# Altium schematic sheet Debug.SchDoc(Debug)
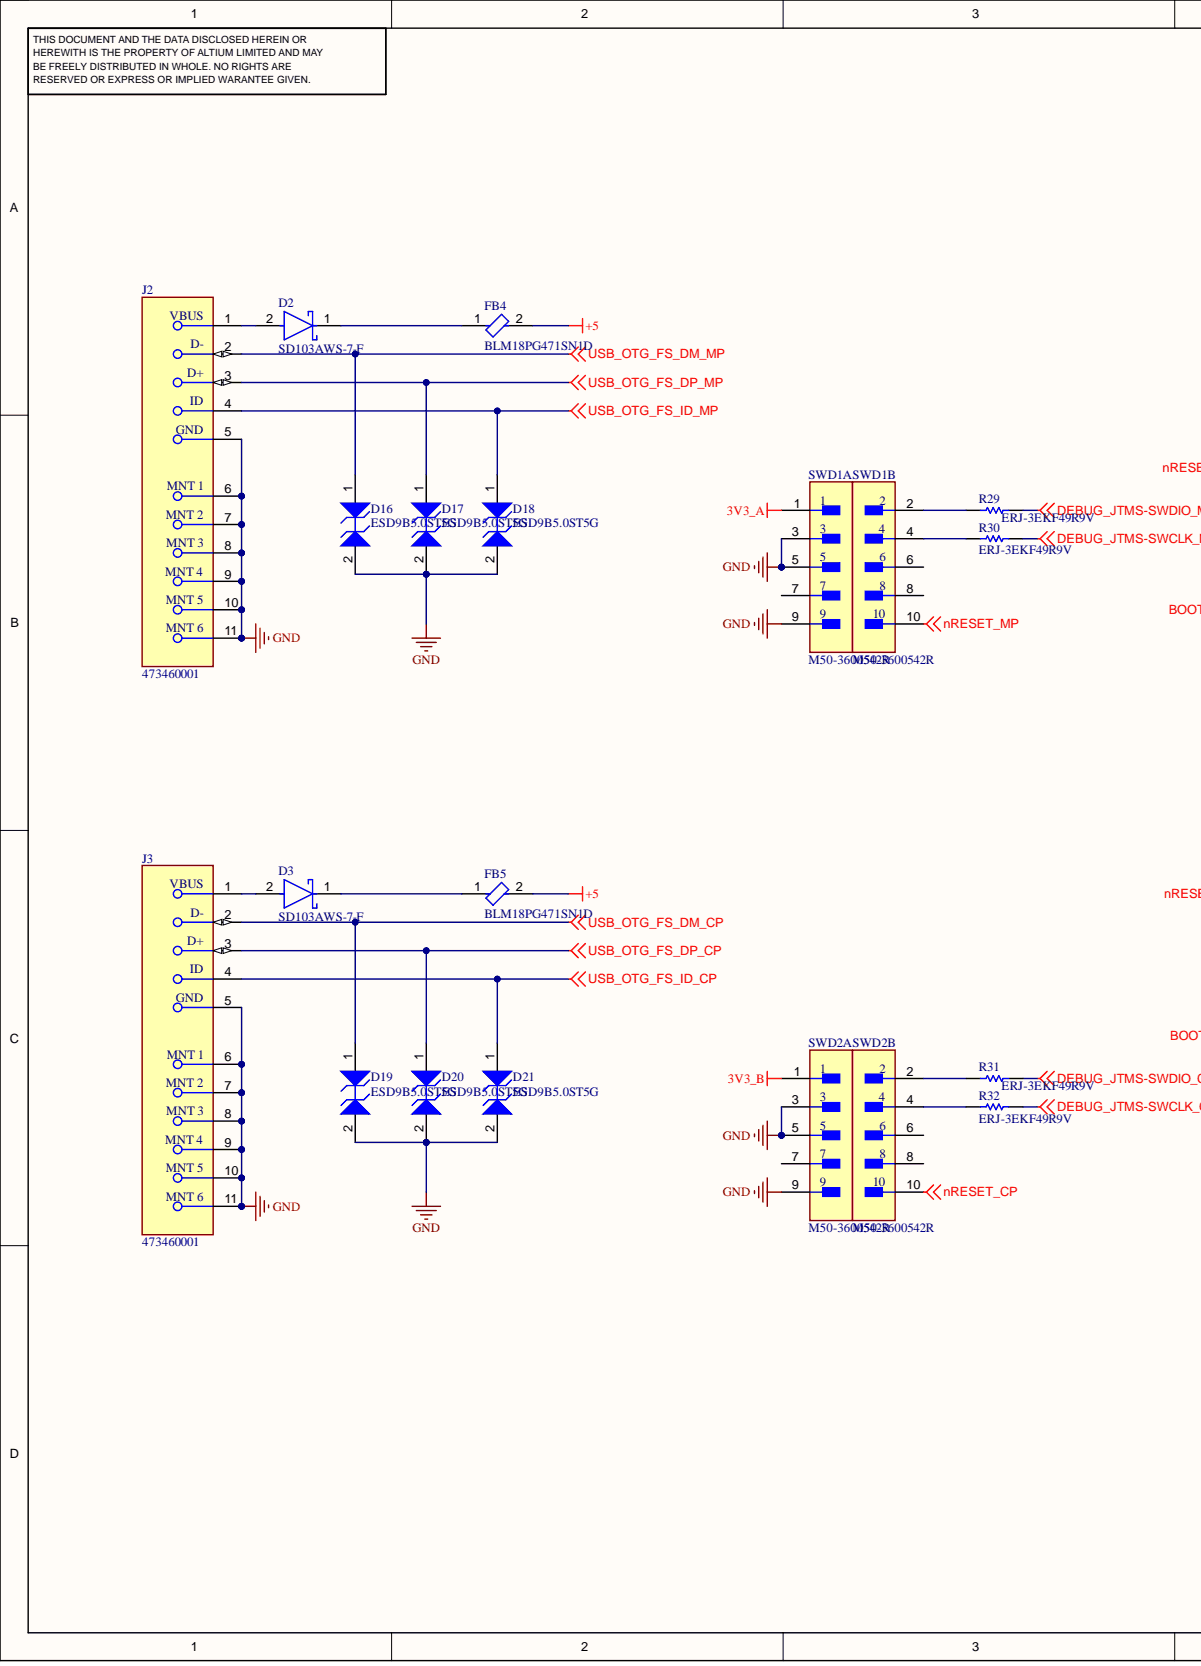
[diagram p0: Debug.SchDoc, left]
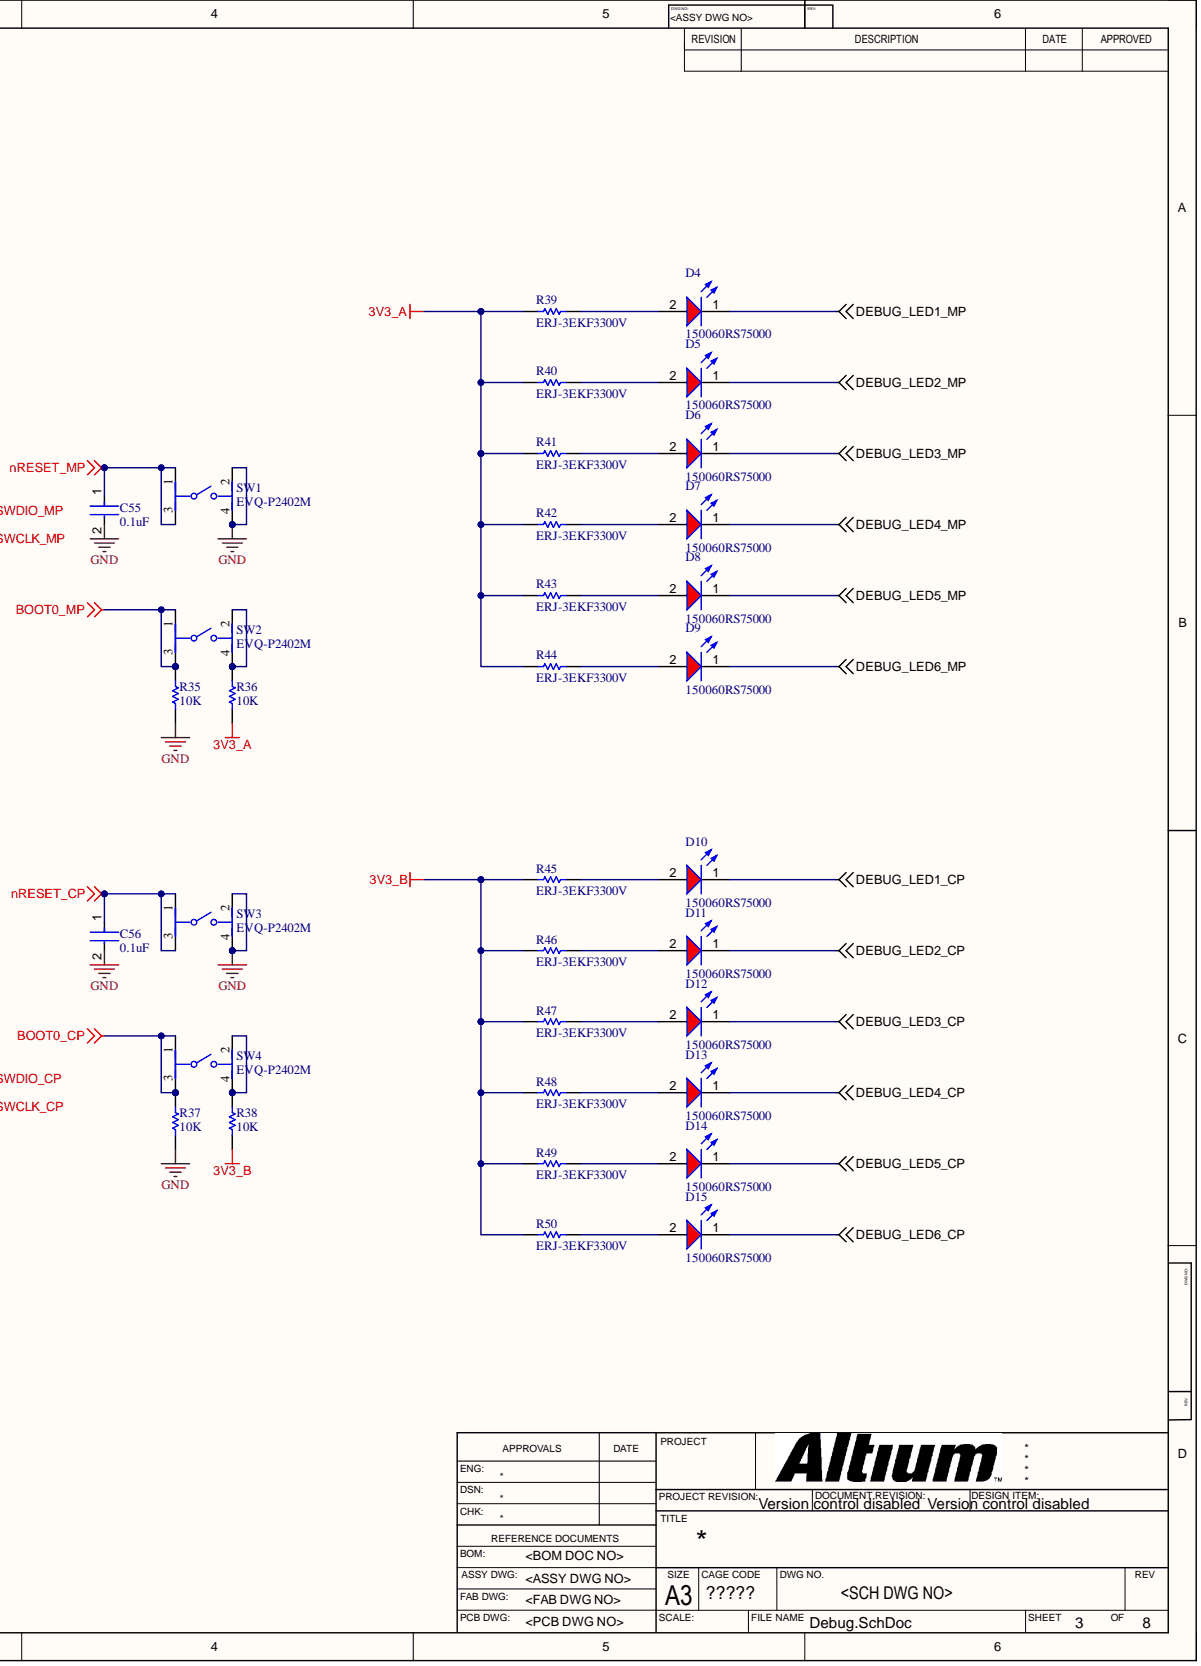
[diagram p1: Debug.SchDoc, right]

## Parts
C55  0.1uF
C56  0.1uF
D2  SD103AWS-7-F
D3  SD103AWS-7-F
D4  150060RS75000
D5  150060RS75000
D6  150060RS75000
D7  150060RS75000
D8  150060RS75000
D9  150060RS75000
D10  150060RS75000
D11  150060RS75000
D12  150060RS75000
D13  150060RS75000
D14  150060RS75000
D15  150060RS75000
D16  ESD9B5.0ST5G
D17  ESD9B5.0ST5G
D18  ESD9B5.0ST5G
D19  ESD9B5.0ST5G
D20  ESD9B5.0ST5G
D21  ESD9B5.0ST5G
FB4  BLM18PG471SN1D
FB5  BLM18PG471SN1D
J2  473460001
J3  473460001
R29  ERJ-3EKF49R9V
R30  ERJ-3EKF49R9V
R31  ERJ-3EKF49R9V
R32  ERJ-3EKF49R9V
R35  10K
R36  10K
R37  10K
R38  10K
R39  ERJ-3EKF3300V
R40  ERJ-3EKF3300V
R41  ERJ-3EKF3300V
R42  ERJ-3EKF3300V
R43  ERJ-3EKF3300V
R44  ERJ-3EKF3300V
R45  ERJ-3EKF3300V
R46  ERJ-3EKF3300V
R47  ERJ-3EKF3300V
R48  ERJ-3EKF3300V
R49  ERJ-3EKF3300V
R50  ERJ-3EKF3300V
SW1  EVQ-P2402M
SW2  EVQ-P2402M
SW3  EVQ-P2402M
SW4  EVQ-P2402M
SWD1  M50-3600542R  (parts A,B)
SWD2  M50-3600542R  (parts A,B)

## Nets
3V3_A: R36.1 R39.1 R40.1 R41.1 R42.1 R43.1 R44.1 SWD1.1
3V3_B: R38.1 R45.1 R46.1 R47.1 R48.1 R49.1 R50.1 SWD2.1
+5: FB4.2 FB5.2
BOOT0_CP: R37.2 SW4.1 SW4.3
BOOT0_MP: R35.2 SW2.1 SW2.3
DEBUG_JTMS-SWCLK_CP: R32.2
DEBUG_JTMS-SWCLK_MP: R30.2
DEBUG_JTMS-SWDIO_CP: R31.2
DEBUG_JTMS-SWDIO_MP: R29.2
DEBUG_LED1_CP: D10.1
DEBUG_LED1_MP: D4.1
DEBUG_LED2_CP: D11.1
DEBUG_LED2_MP: D5.1
DEBUG_LED3_CP: D12.1
DEBUG_LED3_MP: D6.1
DEBUG_LED4_CP: D13.1
DEBUG_LED4_MP: D7.1
DEBUG_LED5_CP: D14.1
DEBUG_LED5_MP: D8.1
DEBUG_LED6_CP: D15.1
DEBUG_LED6_MP: D9.1
GND: C55.2 C56.2 D16.2 D17.2 D18.2 D19.2 D20.2 D21.2 J2.5 J2.6 J2.7 J2.8 J2.9 J2.10 J2.11 J3.5 J3.6 J3.7 J3.8 J3.9 J3.10 J3.11 R35.1 R37.1 SW1.2 SW1.4 SW3.2 SW3.4 SWD1.3 SWD1.5 SWD1.9 SWD2.3 SWD2.5 SWD2.9
nRESET_CP: C56.1 SW3.1 SW3.3 SWD2.10
nRESET_MP: C55.1 SW1.1 SW1.3 SWD1.10
USB_OTG_FS_DM_CP: D19.1 J3.2
USB_OTG_FS_DM_MP: D16.1 J2.2
USB_OTG_FS_DP_CP: D20.1 J3.3
USB_OTG_FS_DP_MP: D17.1 J2.3
USB_OTG_FS_ID_CP: D21.1 J3.4
USB_OTG_FS_ID_MP: D18.1 J2.4
NetD2_1: D2.1 FB4.1
NetD2_2: D2.2 J2.1
NetD3_1: D3.1 FB5.1
NetD3_2: D3.2 J3.1
NetD4_2: D4.2 R39.2
NetD5_2: D5.2 R40.2
NetD6_2: D6.2 R41.2
NetD7_2: D7.2 R42.2
NetD8_2: D8.2 R43.2
NetD9_2: D9.2 R44.2
NetD10_2: D10.2 R45.2
NetD11_2: D11.2 R46.2
NetD12_2: D12.2 R47.2
NetD13_2: D13.2 R48.2
NetD14_2: D14.2 R49.2
NetD15_2: D15.2 R50.2
NetR29_1: R29.1 SWD1.2
NetR30_1: R30.1 SWD1.4
NetR31_1: R31.1 SWD2.2
NetR32_1: R32.1 SWD2.4
NetR36_2: R36.2 SW2.2 SW2.4
NetR38_2: R38.2 SW4.2 SW4.4

Not connected: SWD1 6 7 8; SWD2 6 7 8

## Library data of the parts
C55 C56 = CMP-2006-03061-2: CAP CER 0.1UF 50V X7R 0603; Manufacturer: KEMET; Manufacturer Part Number: C0603C104K5RACTU; Automotive Grade: Grade 1; Capacitance: 0.10 μF; Material: Ceramic; Temperature Coefficient: 30ppm/°C; Tolerance: 0.1; Value: 0.10 μF; Automotive: Yes; Category: Cap; Component Kind: Standard; ComponentLink2Description: Datasheet; ComponentLink2URL: https://api.kemet.com/component-edge/download/datasheet/C0603C100J5GACAUTO.pdf; Device Class L1: Passive Components; Device Class L2: Capacitors; Device Class L3: Ceramic Capacitors; Digikey Description: CAP CER 0.1UF 50V X7R 0603; Height: 0.87mm; Lead Free: Yes; Library Name: Altium Content Vault; Octopart: https://octopart.com/c0603c104k5ractu-kemet-139244; Package: C0603C-CF; RoHS: TRUE; Temperature: -55°C to +125°C; Temperature Characteristic: X7R; Temperature Range High: +125°C; Temperature Range Low: -55°C; Voltage Rating: 50 V
D2 D3 = CMP-12790-000048-1: DIODE SCHOTTKY 40V 350MA SOD323; Manufacturer: Diodes Inc.; Automotive Grade: Grade 1; Capacitance: 35pF; Max Junction Temp: +125°C; Max Surge Forward Current: 1.5A; Peak Reverse Current: 5uA; Standoff Height: 0.05mm; Value: 35pF; Automotive: Yes; Category: Diode; Component Kind: Standard; ComponentLink2Description: Datasheet; ComponentLink2URL: https://www.diodes.com/assets/Datasheets/ds30101.pdf; Device Class L1: Discrete Semiconductors; Device Class L2: Diodes; Device Class L3: Schottky Diodes; Digikey Description: DIODE SCHOTTKY 40V 350MA SOD323; Footprint Url: https://www.diodes.com/assets/Package-Files/SOD323.pdf; Forward Current: 350mA; Height: 1.2mm; Ipc Land Pattern Name: SOD250130X110-2; Lead Free: Yes; Library Name: Altium Content Vault; Manufacturer Part Number: SD103AWS-7-F; Max Repetitive Reverse Voltage: 40V; Mouser Description: Schottky Diodes & Rectifiers 40V 200mW; Octopart: https://octopart.com/sd103aws-7-f-diodes+inc.-55389602; Package: SOD323; Reverse Voltage: 40V; RoHS: TRUE; Temperature: -65°C to +125°C; Temperature Range High: +125°C; Temperature Range Low: -65°C
D4-D15 = CMP-1426-00001-4: LED Low-Power Uni-Color Red 630nm 2V 2-Pin Chip 0603(1608Metric) T/R; Dominant Wavelength: 625nm; Forward Current: 100mA; Lens Style: Clear, Rectangular; Luminous Intensity: 250mcd; Max Junction Temperature (Tj): 95°C; Max Reverse Voltage (DC): 5V; Power Dissipation: 72mW; Test Current: 20mA; Viewing Angle: 140°; Case Code (Imperial): 0603; Case Code (Metric): 1608; Case/Package: 0603; Color: Red; Component Kind: Standard; Forward Voltage: 2V; Height: 700µm; Length: 1.6mm; Library Name: Altium Content Vault; Max Operating Temperature: 85°C; Min Operating Temperature: -40°C; Mount: Surface Mount; Packaging: Tape and Reel; Peak Wavelength: 630nm; REACH SVHC: Unknown; Reverse Voltage: 5V; RoHS: Compliant; Wavelength: 625nm; Width: 800µm
D16-D21 = CMP-1063-00119-1: Transient Voltage Suppressor, Micro-Packaged Diode for ESD Protection, 2-Pin SOD-923, Pb-Free, Tape and Reel; Manufacturer: On Semiconductor; Direction: Bidirectional; IR Max (uA): 1; V(BR) Min (V): 5.8; VRWM Max (V): 5; Component Kind: Standard; ComponentLink1Description: Manufacturer URL; ComponentLink1URL: http://www.onsemi.com/; ComponentLink2Description: Datasheet; ComponentLink2URL: http://www.onsemi.cn/pub_link/Collateral/ESD9B-D.PDF; ComponentLink3Description: Package Specification; ComponentLink3URL: http://www.onsemi.com/pub_link/Collateral/514AB.PDF; DatasheetVersion: Rev. 2; Library Name: Altium Content Vault; Mounting Technology: Surface Mount; Number of Lines: 1; PackageDescription: 2-Pin SOD, Body 0.8 x 0.6 mm; PackageReference: SOD-923-2-514AB-01; PackageVersion: Rev. C, 03/2011; Packing: Tape and Reel; PartNumber: ESD9B5.0ST5G; RoHS: Pb-Free
FB4 FB5 = CMP-0686-00587-3: Chip Ferrite Bead, 0603, 470Ω @ 100MHz, 0.2Ω, 25%, 1A; DC Resistance (DCR): 200mR; Impedance: 470R; Material: Steel; Number of Circuits: 1; Case Code (Imperial): 0603; Case Code (Metric): 1608; Case/Package: 0603; Component Kind: Standard; Core Material: Ferrite; Current Rating: 1A; DC Current: 1A; DC Resistance-Max: 200mR; Depth: 0.8mm; Height: 0.8mm; Lead Free: Lead Free; Length: 1.6mm; Library Name: Altium Content Vault; Max Current Rating: 1A; Max DC Current: 1A; Max Operating Temperature: 125°C; Military Standard: Not; Min Operating Temperature: -55°C; Number of Lines: 1; Number of Pins: 2; Package Quantity: 1; Packaging: Tape and Reel; Radiation Hardening: No; REACH SVHC: No SVHC; Resistance: 200mR; RoHS Compliant: Yes; Series: BLM18P; Termination: SMD/SMT; Test Frequency: 100MHz; Tolerance: 25%; Width: 0.8mm
J2 J3 = CMP-2000-05246-2: CONN RCPT USB2.0 MICRO B SMD R/A; Manufacturer: Molex; Conn Orientation: Right Angle; Current Rating: 1.8A; Is Female: yes; Number Of Contacts: 5; Pitch: 0.65mm; Shielding: true; Voltage Rating: 30V; Automotive: No; Category: Conn; Component Kind: Standard; ComponentLink2Description: Datasheet; ComponentLink2URL: https://www.molex.com/webdocs/datasheets/pdf/en-us/0473460001_IO_CONNECTORS.pdf; Contact Material: Gold,Nickel; Device Class L1: Connectors; Device Class L2: USB Connectors; Device Class L3: unset; Digikey Description: CONN RCPT USB2.0 MICRO B SMD R/A; Footprint Url: https://www.molex.com/pdm_docs/sd/473460001_sd.pdf; Height: 2.945mm; Lead Free: Yes; Library Name: Altium Content Vault; Manufacturer Part Number: 473460001; Octopart: https://octopart.com/47346-0001-molex-7164928; Package: 0; RoHS: TRUE; Temperature: -30°C to +85°C; Temperature Range High: +85°C; Temperature Range Low: -30°C; Usb Standard: USB 2.0
R29-R32 = CMP-2000-00538-1: Manufacturer: Panasonic; Component Kind: Standard; Library Name: Altium Content Vault; Part Number: ERJ-3EKF49R9V
R35-R38 = CMP-1012-00623-3: Chip Resistor, 10 KOhm, +/- 1%, 0.1 W, -55 to 155 degC, 0603 (1608 Metric), RoHS, Tape and Reel; Manufacturer: Panasonic; Case\/Package: 0603; Max Power Dissipation: 100mW; Resistance: 10KOhm; Temperature Coefficient: 100ppm\/degreesC; Voltage Rating: 75V; Case Code (Imperial): 0603; Case Code (Metric): 1608; Component Kind: Standard; Composition: ThickFilm; Contact Plating: Tin; Datasheet URL: https://industrial.panasonic.com/cdbs/www-data/pdf/RDA0000/AOA0000C304.pdf; Datasheet Version: 02/2017; Depth: 0.8mm; Height: 0.45mm; Lead Free: LeadFree; Length: 1.6mm; Library Name: Altium Content Vault; Manufacturer URL: http://www.panasonic.com/; Max Operating Temperature: 155degC; Military Standard: Not; Min Operating Temperature: -55degC; Mount: SurfaceMount; Mounting Technology: SurfaceMount; Number of Pins: 2; Number of Terminations: 2; Package Description: 2-Pin Surface Mount Device, Body 1.6 x 0.85 mm; Package Quantity: 1; Package Reference: 603; Packaging: TapeandReel; Power Rating: 100mW; Radiation Hardening: No; REACH SVHC: NoSVHC; RoHSCompliant: true; Termination: SMD/SMT; Tolerance: 1%; Voltage Rating (DC): 75V; Width: 0.031inch
R39-R50 = CMP-2000-00435-2: Chip Resistor, 330 Ohm, +/- 1%, 0.1 W, -55 to 155 degC, 0603 (1608 Metric), RoHS, Tape and Reel; Current Rating: 500 mA; Gender: Male; Mating Cycles: 10000; Voltage Rating: 10 V; Component Kind: Standard; ComponentLink1Description: Manufacturer URL; ComponentLink1URL: http://www.panasonic.com/; ComponentLink2Description: Datasheet; ComponentLink2URL: http://industrial.panasonic.com/cdbs/www-data/pdf/RDA0000/AOA0000C86.pdf; Contact Finish: Gold; Contact Material: Copper; Contact Plating: Gold; DatasheetVersion: Apr-15; Height: 1.45 mm; Lead Pitch: 1.1 mm; Length: 13.7 mm; Library Name: Altium Content Vault; Max Operating Temperature: 85 degC; Min Operating Temperature: -25 degC; Mount: Surface Mount; Package Quantity: 1500; PackageDescription: 2-Pin Surface Mount Device, Body 1.6 x 0.85 mm; PackageReference: 0603; Packaging: Tape and Reel; Pins: 8; REACH SVHC: No SVHC; RoHSCompliant: true; Width: 1.45 mm
SW1-SW4 = CMP-05618-000087-1: SWITCH TACTILE SPST-NO 0.02A 15V; Actuator Color: Natural; Actuator Length: 0.45mm; Contact Current Rating: 20mA; Contact Resistance: 100mR; Electrical Life: 500000Cycles; Illumination Type: Non-Illuminated; Operating Force: 2.4N; Switch Function: Off-Mom; Switch Travel: 0.25mm; Throw Configuration: SPST; Color: Clear; Component Kind: Standard; Current Rating: 20mA; Depth: 5.5mm; Height: 2.1mm; Lead Free: Lead Free; Length: 3.5mm; Library Name: Altium Content Vault; Max Current Rating: 20mA; Max Operating Temperature: 85°C; Max Voltage Rating (DC): 15V; Mechanical Life: 500000Cycles; Min Operating Temperature: -40°C; Mount: Surface Mount; Orientation: Straight; Package Quantity: 1; Packaging: Tape and Reel; Radiation Hardening: No; REACH SVHC: No SVHC; RoHS Compliant: Yes; Series: EVQP2; Size: 3.5mm; Termination: J-Bend; Voltage Rating (DC): 15V; Width: 3.5mm
SWD1 SWD2 = CMP-15831-000038-1: CONN HEADER SMD 10POS 1.27MM; Contact Resistance: 30mR; Gender: Male; Max Current Rating: 1A; Number of Contacts: 10; Component Kind: Standard; Contact Material: Copper Alloy; Contact Plating: Gold; Depth: 3.41mm; Housing Material: Thermoplastic; Length: 6.35mm; Library Name: Altium Content Vault; Max Operating Temperature: 105°C; Min Operating Temperature: -40°C; Number of Rows: 2; Orientation: Straight; Pitch: 1.27mm; Radiation Hardening: No; RoHS Compliant: Yes; Termination: Solder
